# Altium schematic sheet 2. RS485.SchDoc("2. RS485")
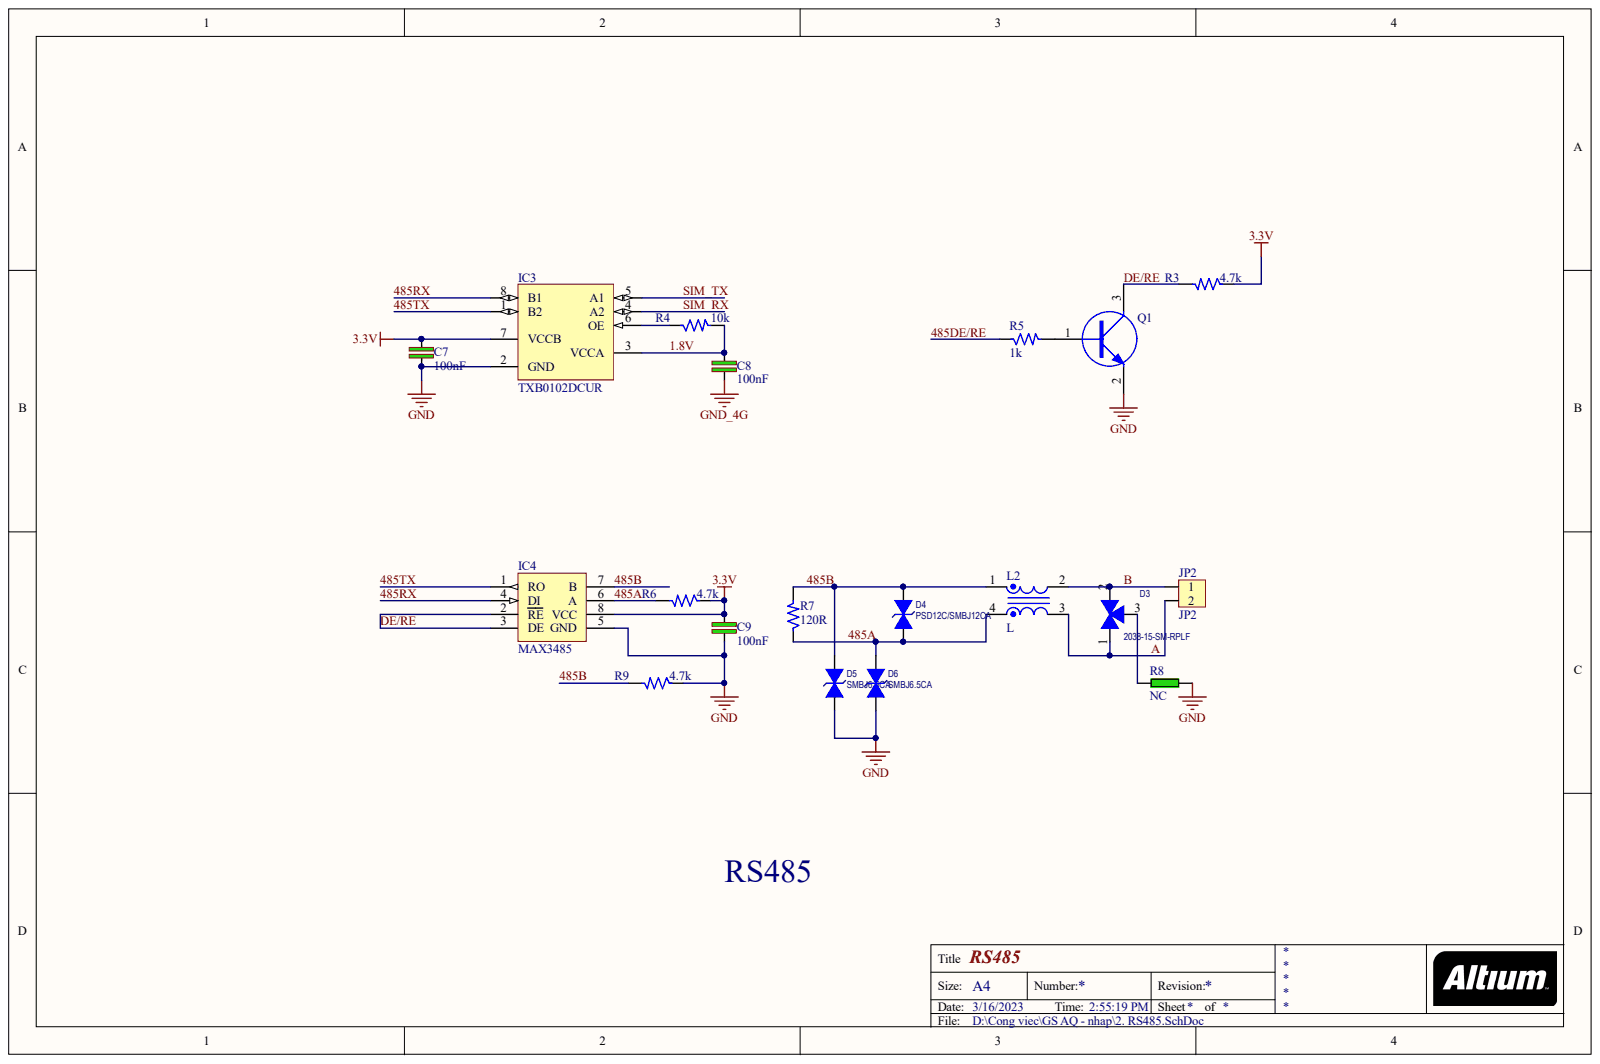
[diagram p0: 2. RS485.SchDoc]

## Parts
C7  100nF  [C-0805]
C8  100nF  [C-0805]
C9  100nF  [C-0805]
D3  2038-15-SM-RPLF  [2038-15-SM-RPLF]
D4  PSD12C/SMBJ12CA  [SOD323 - DO241]
D5  SMBJ6.5CA  [DO-214AA]
D6  SMBJ6.5CA  [DO-214AA]
IC3  TXB0102DCUR  [US8]
IC4  MAX3485  [SOIC-8 - 1]
JP2  JP2  [KF2-5.08]
L2  L  [L-B82793S0513N201]
Q1  C1815(MMBT4401)  [SOT23-3]  (value not drawn)
R3  4.7k  [R-0805]
R4  10k  [R-0805]
R5  1k  [R-0805]
R6  4.7k  [R-0805]
R7  120R  [R-0805]
R8  NC  [R-0805]
R9  4.7k  [R-0805]

## Nets
3.3V: C7.1 C9.1 IC3.7(VCCB) IC4.8(VCC) R3.1 R6.1
GND: C7.2 C9.2 D5.1 D6.1 IC3.2(GND) IC4.5(GND) Q1.2 R8.2 R9.1
GND_4G: C8.2
1.8V: C8.1 IC3.3(VCCA) R4.2
485A: D4.1 D6.2 IC4.6(A) L2.4 R6.2 R7.1
485B: D4.2 D5.2 IC4.7(B) L2.1 R7.2 R9.2
485DE/RE: R5.1
485RX: IC3.8(B1) IC4.4(DI)
485TX: IC3.1(B2) IC4.1(RO)
A: D3.1 JP2.2 L2.3
B: D3.2 JP2.1 L2.2
DE/RE: IC4.2(RE) IC4.3(DE) Q1.3 R3.2
SIM_RX: IC3.4(A2)
SIM_TX: IC3.5(A1)
NetD3_3: D3.3 R8.1
NetIC3_6: IC3.6(OE) R4.1
NetQ1_1: Q1.1 R5.2

## Library data of the parts
C7-C9 = C: Cap SMD; Manufacturer: Samsung Electro-Mechanics; Part number: CL21B104KBCNNWC; User_Description: CAP CER 0.1UF 50V X7R 0805; Category: CAPACITOR; Component Kind: Standard; Package: 0805; Value: 100nF; Library Name: Anh_Trung.SchLib
D3 = 2038-15-SM-RPLF: GDT 150V 5KA 3 POLE SMD; Manufacturer: Bourns Inc.; Category: Circuit Protection; Component Kind: Standard; Package: 3-SMD Cylinder Square End; Part number: 2038-15-SM-RPLF; User_Description: GDT 150V 5KA 3 POLE SMD; Library Name: Anh_Trung.SchLib
D4-D6 = SMAJ30CA-30V/8.3A: TVS DIODE 30VWM 48.4VC SMA; Manufacturer: Bourns Inc.; Part number: PSD12C; Category: Circuit Protection; Component Kind: Standard; Package: SOD323; User_Description: TVS DIODE 30VWM 48.4VC SMA; Library Name: Anh_Trung.SchLib
IC3 = TXB0102DCUR: IC TRNSLTR BIDIRECTIONAL US8; Manufacturer: Texas Instruments; Category: IC Logic Level; Component Kind: Standard; Package: 8-VSSOP; Part number: TXB0102DCUR; User_Description: IC TRNSLTR BIDIRECTIONAL US8; Library Name: Anh_Trung.SchLib
IC4 = MAX3362EKA+T: IC TRANSCEIVER HALF 1/1 SOT23-8; Manufacturer: Maxim Integrated; Category: Interface - Drivers; Component Kind: Standard; Package: SOT-23-8; Part number: MAX3362EKA+T; User_Description: IC TRANSCEIVER HALF 1/1 SOT23-8; Library Name: Anh_Trung.SchLib
JP2 = Con2: Connector 2; Component Kind: Standard; Value: Connector 2; Library Name: Anh_Trung.SchLib
L2 = L filter Common Mode Chokes: CMC 51UH 800MA 2LN SMD AEC-Q200; Manufacturer: EPCOS - TDK Electronics; Part number: B82793S0513N201; Component Kind: Standard; User_Description: CMC 51UH 800MA 2LN SMD AEC-Q200; Library Name: Anh_Trung.SchLib
Q1 = NPN: Transistor C1815, SOT23; Manufacturer: Fairchild Semiconductor; User_Description: 0.6A, 40V, NPN; Category: TRAN NPN; Component Kind: Standard; Package: SOT-23; Part number: MMBT4401; Library Name: Anh_Trung.SchLib
R3 R6 R9 = R: RES SMD 4.7K OHM 1% 1/8W 0805; Manufacturer: Yageo; Part number: RC0805FR-074K7L; Category: RESISTORS; Component Kind: Standard; Package: 0805; User_Description: RES SMD 4.7K OHM 1% 1/8W 0805; Value: 4.7k; Library Name: Anh_Trung.SchLib
R4 = R: Resistor 1/4W; Manufacturer: Yageo; Part number: RC0805FR-0710KL; User_Description: RES SMD 10K OHM 1% 1/8W 0805; Category: RESISTORS; Component Kind: Standard; Package: 0805; Value: 10k; Library Name: Anh_Trung.SchLib
R5 = R: Resistor 1/4W; Manufacturer: Yageo; Part number: RC0805FR-071KL; User_Description: RES SMD 1K OHM 1% 1/8W 0805; Category: RESISTORS; Component Kind: Standard; Package: 0805; Value: 1k; Library Name: Anh_Trung.SchLib
R7 = R: Resistor 1/4W; Manufacturer: Yageo; Part number: RC0805FR-07120RL; User_Description: RES SMD 120 OHM 1% 1/8W 0805; Category: RESISTORS; Component Kind: Standard; Package: 0805; Value: 120R; Library Name: Anh_Trung.SchLib
R8 = RES: Resistor 1/4W; Value: R1/4W - 1K; Component Kind: Standard; Library Name: heromap.SchLib
